# Altium schematic sheet [03] - PowerSupply.SchDoc
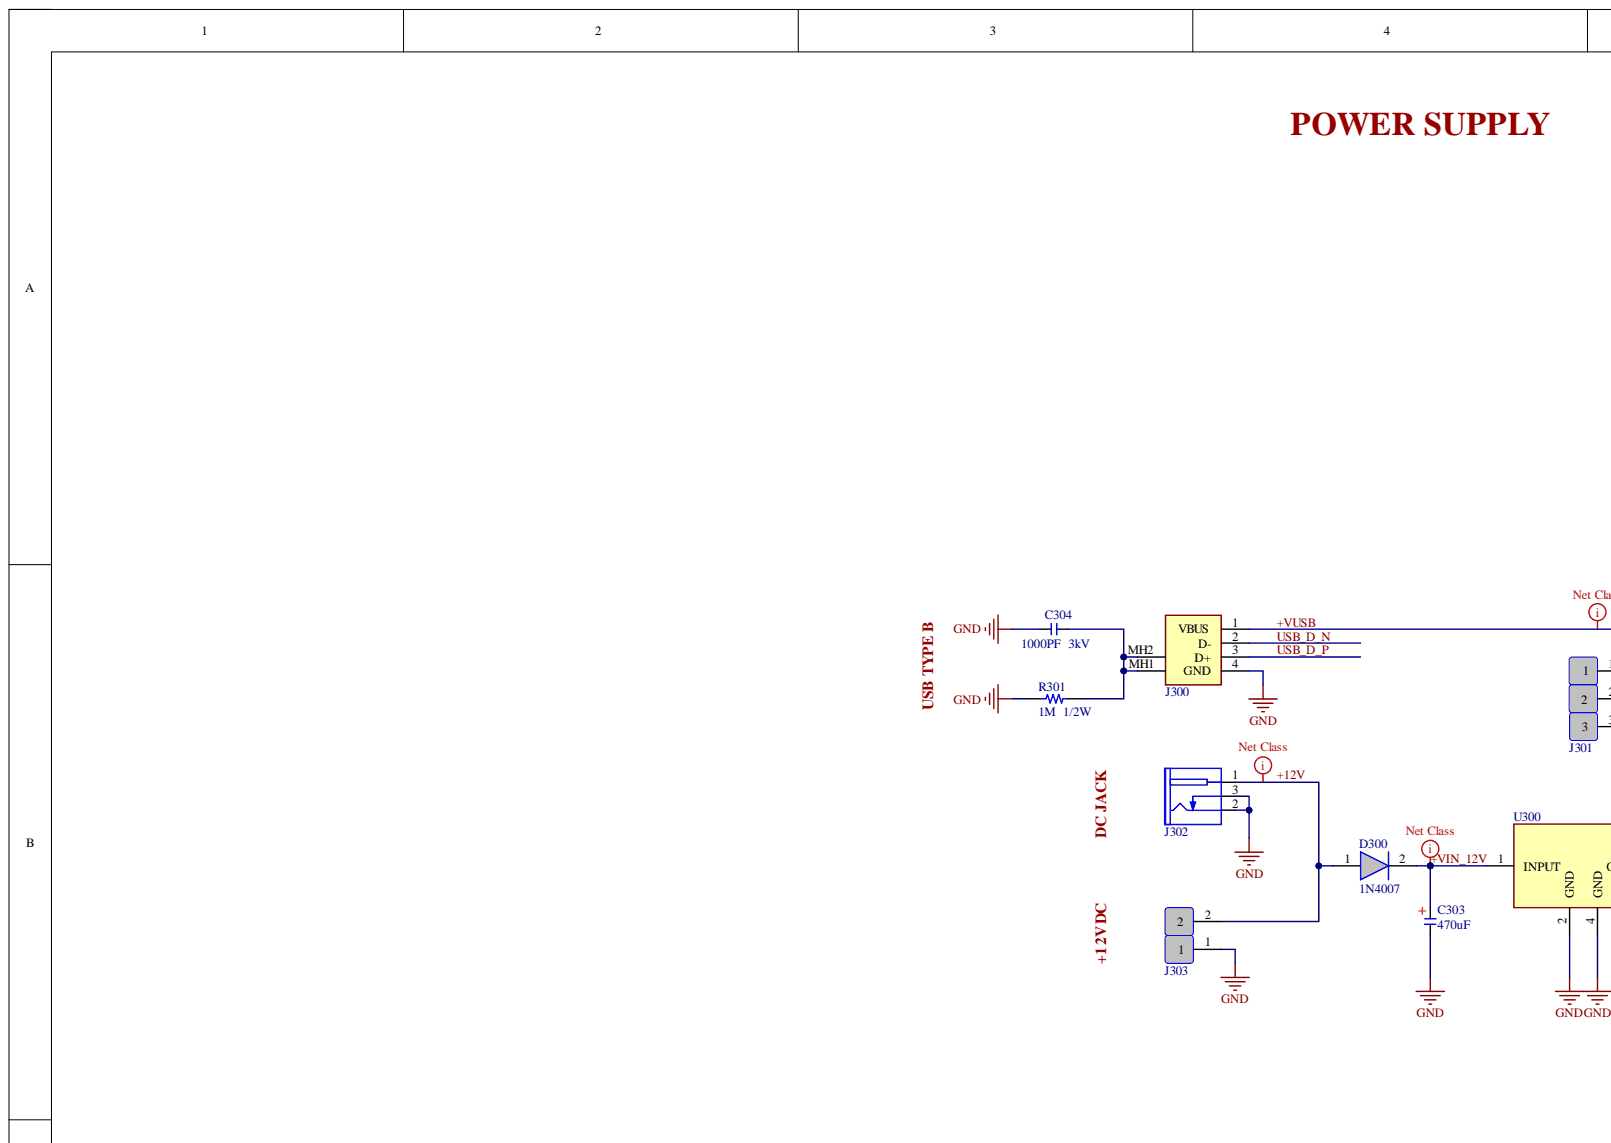
[diagram p0: [03] - PowerSupply.SchDoc, top left]
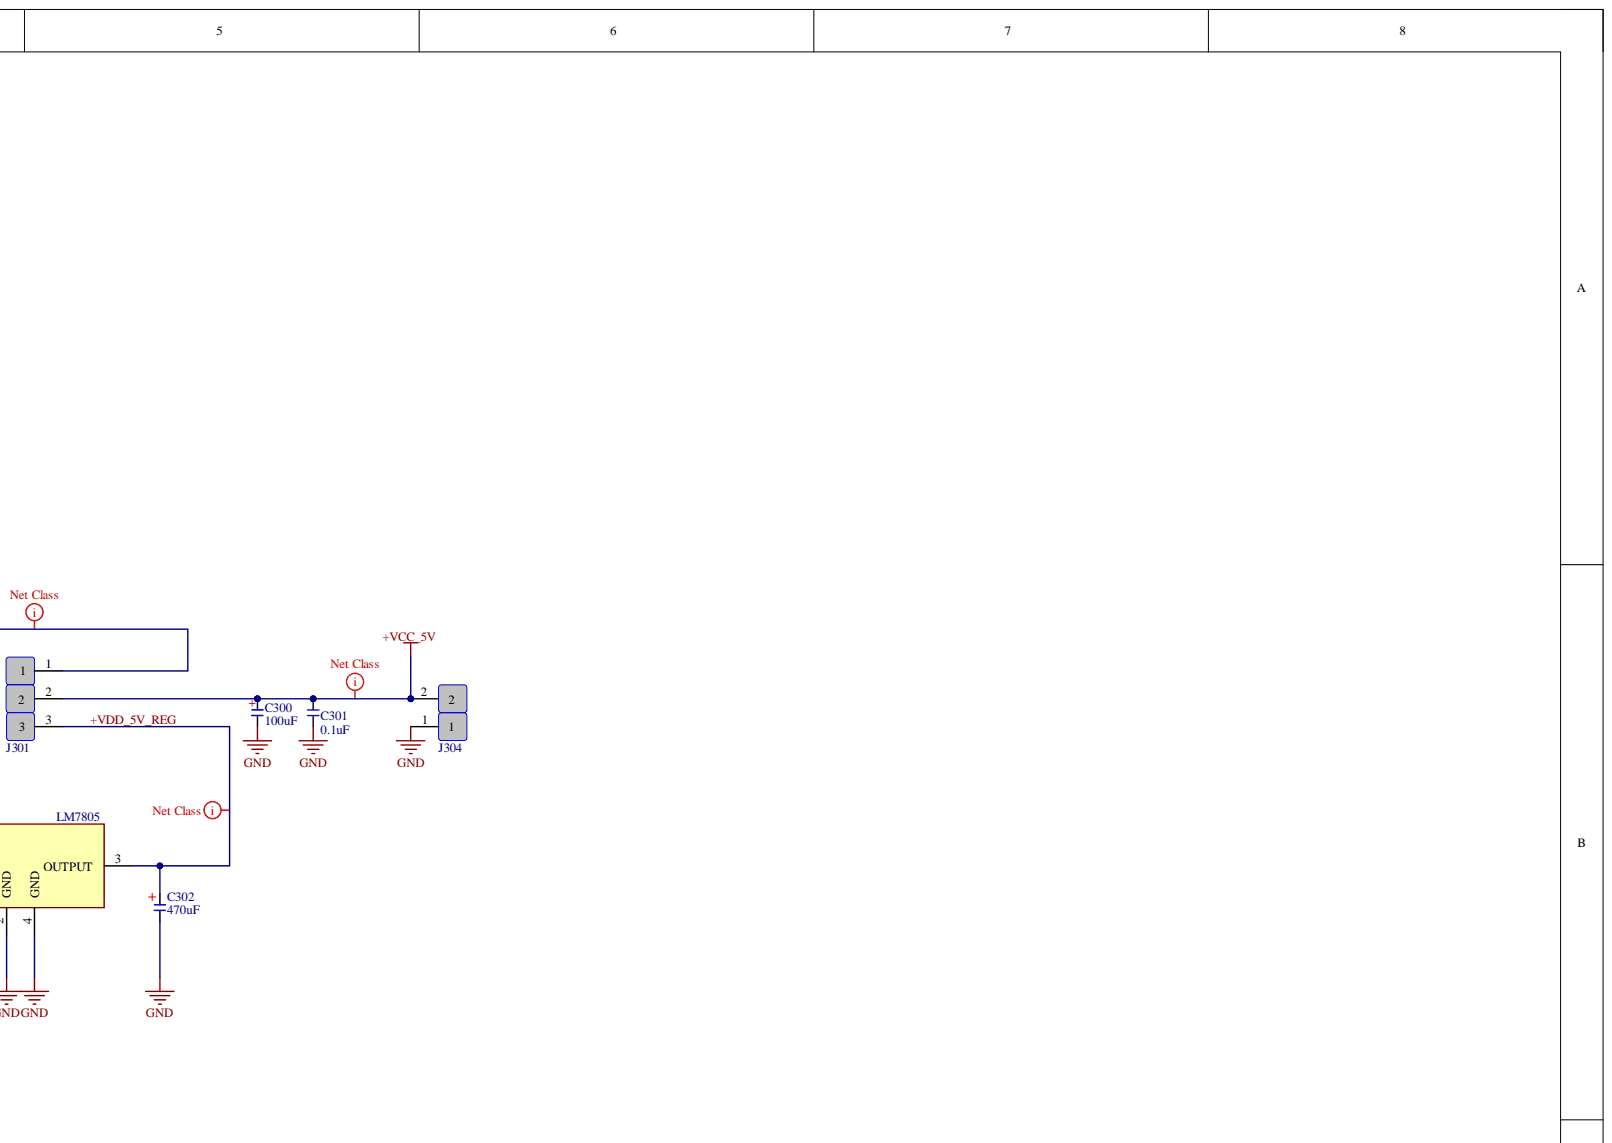
[diagram p1: [03] - PowerSupply.SchDoc, top right]
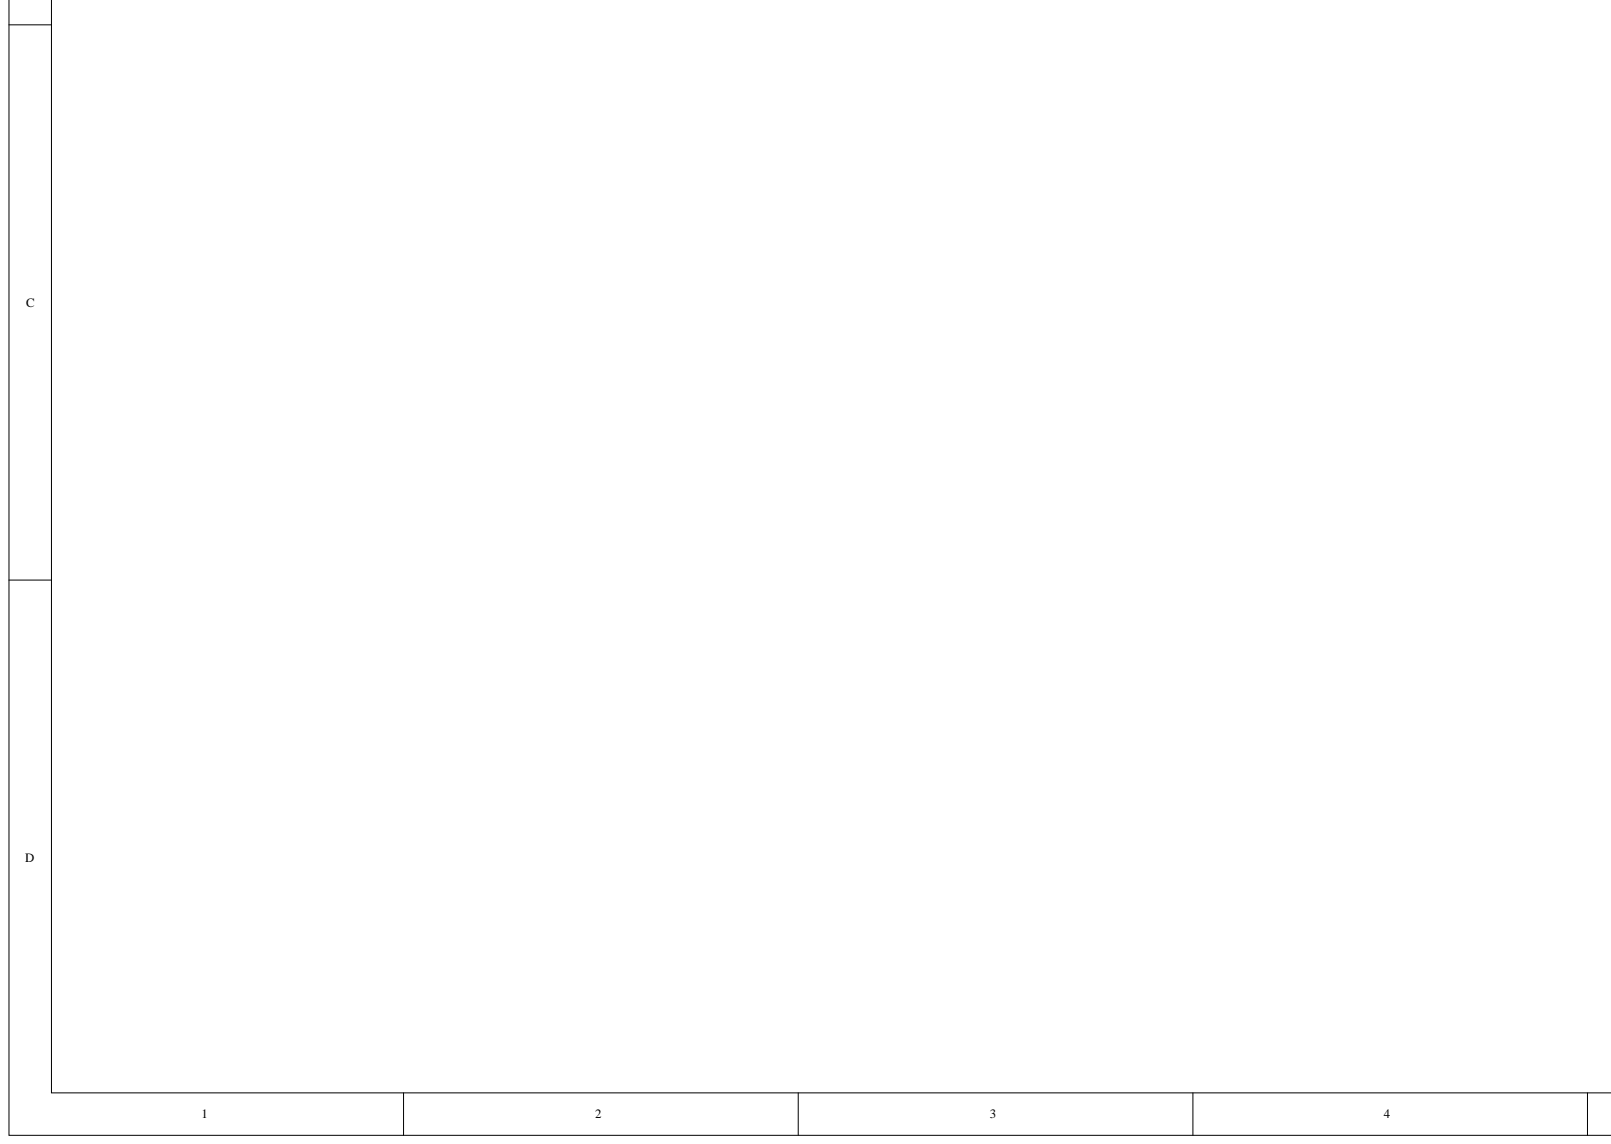
[diagram p2: [03] - PowerSupply.SchDoc, bottom left]
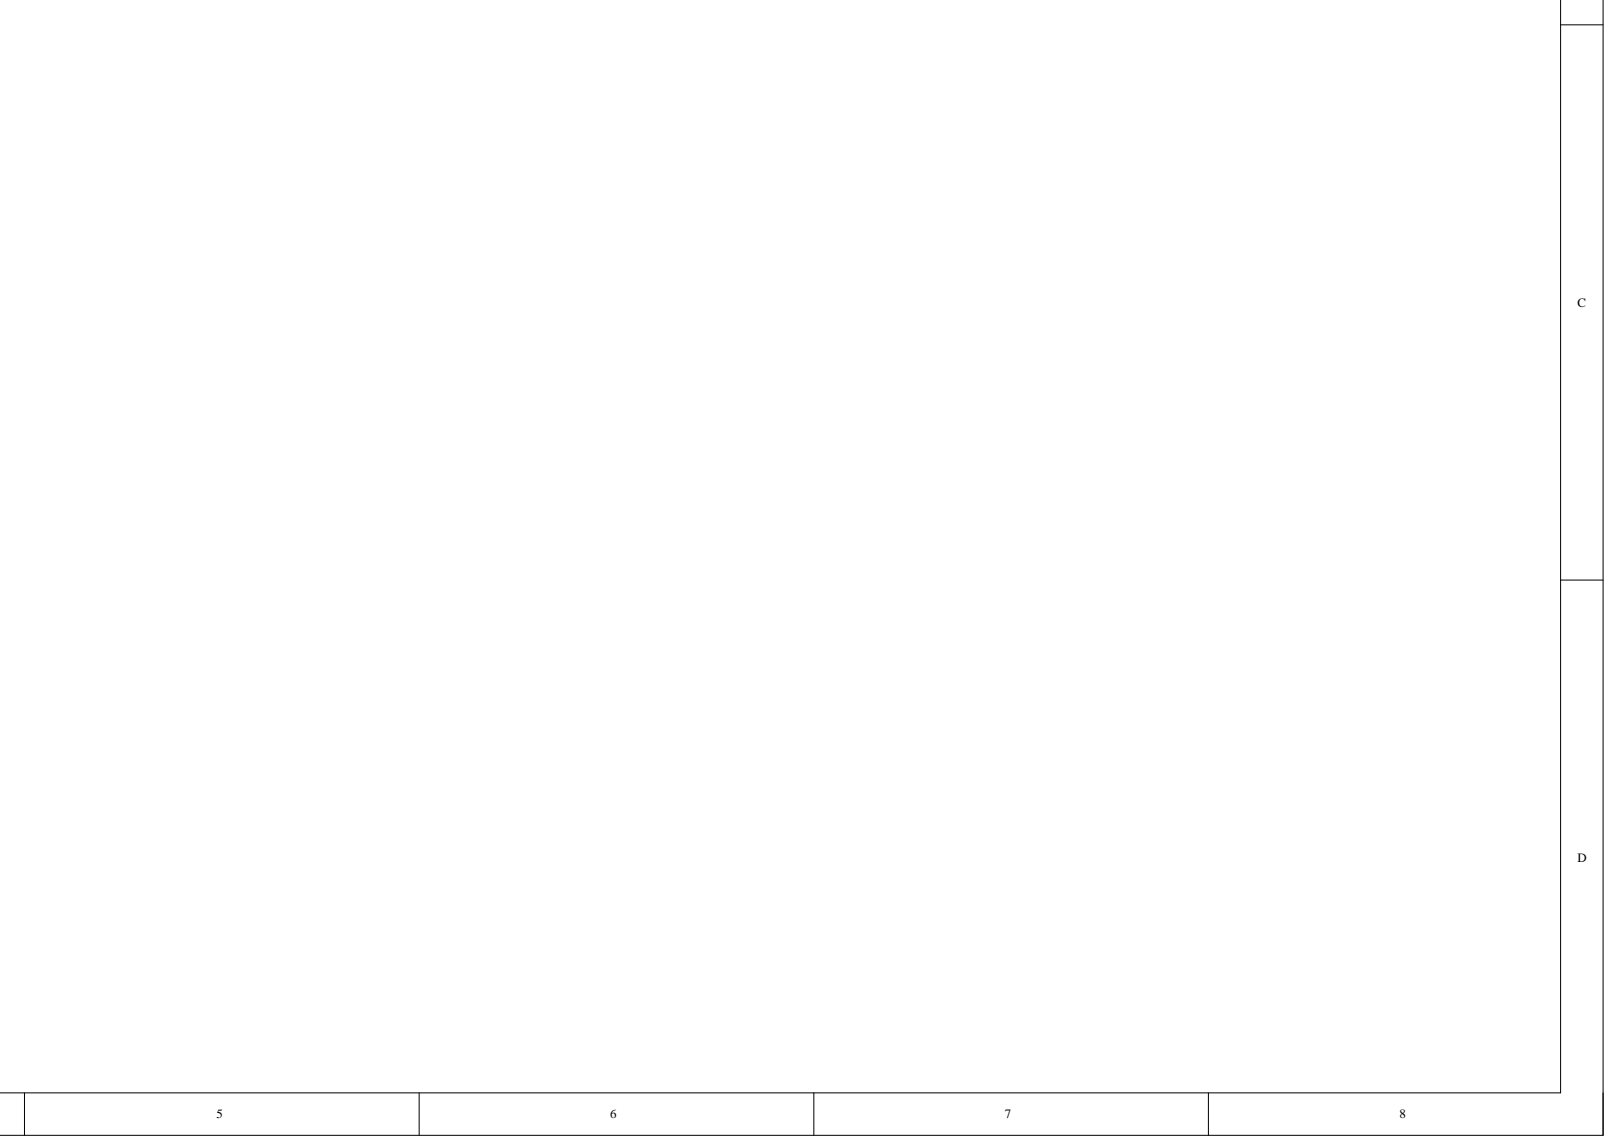
[diagram p3: [03] - PowerSupply.SchDoc, bottom right]

## Parts
C300  100uF  [1411]
C301  0.1uF  [C0402]
C302  470uF  [470uF]
C303  470uF  [470uF]
C304  1000PF  3kV  Voltage Rating: 3kV  [C1812]
D300  1N4007  [1N4007]
J300  SS-52300-001  [USB _Type_B]  (value not drawn)
J301  61300311121  [Con_3Pin]  (value not drawn)
J302  PJ-002BH  [POWER_JACK_5.5_2.1MM_NS_V1.1]  (value not drawn)
J303  Con_2Pin  [61300211121]  (value not drawn)
J304  Con_2Pin  [61300211121]  (value not drawn)
R301  1M  1/2W  [R0805]
U300  LM7805  [7805_SOT223]

## Nets
+VCC_5V: C300.1 C301.1 J301.2 J304.2
GND: C300.2 C301.2 C302.2 C303.2 C304.1 J300.4(GND) J302.2 J302.3 J303.1 J304.1 R301.2 U300.2(GND) U300.4(GND)
+12V: D300.1 J302.1 J303.2
+VDD_5V_REG: C302.1 J301.3 U300.3(OUTPUT)
+VIN_12V: C303.1 D300.2 U300.1(INPUT)
+VUSB: J300.1(VBUS) J301.1
USB_D_N: J300.2(D-)
USB_D_P: J300.3(D+)
NetC304_2: C304.2 J300.MH1 J300.MH2 R301.1

## Library data of the parts
C300 = C_100uF: KEMET - T520B107M006ATE018 - Tantalum Polymer Capacitor, KO-CAP®, 100 µF, 6.3 V, T52X KO-CAP® Series, ± 20%, B, 0.018 ohm; Dissipation Factor: 8%; ESR (Equivalent Series Resistance): 18mR; Life (Hours): 2000Hour; Polarity: Polar; Resistance: 0.018R; Voltage Rating: 6.3V; Capacitance: 100uF; Case Code (Imperial): 1411; Case Code (Metric): 3528; Case/Package: 1411; Category: Polymer Capacitors; Component Kind: Standard; ComponentLink1Description: https://datasheet.ciiva.com/22691/1759375-22691466.pdf?src-supplier=Element14; ComponentLink1URL: https://datasheet.ciiva.com/22691/1759375-22691466.pdf?src-supplier=Element14; ComponentLink2Description: https://datasheet.ciiva.com/260/kem-t2015-t520-260432.pdf?src-supplier=Digi-Key; ComponentLink2URL: https://datasheet.ciiva.com/260/kem-t2015-t520-260432.pdf?src-supplier=Digi-Key; ComponentLink3Description: https://datasheet.ciiva.com/260/kem-t2015-t520-260430.pdf?src-supplier=Digi-Key; ComponentLink3URL: https://datasheet.ciiva.com/260/kem-t2015-t520-260430.pdf?src-supplier=Digi-Key; ComponentLink4Description: https://datasheet.ciiva.com/260/kem-t2015-t520-260431.pdf?src-supplier=Digi-Key; ComponentLink4URL: https://datasheet.ciiva.com/260/kem-t2015-t520-260431.pdf?src-supplier=Digi-Key; Depth: 2.8mm; Dielectric Material: Tantalum; Features: General Purpose; Height: 2.1mm; Height - Seated (Max): 0.083inch; Length: 3.5mm; Library Name: Passive.SchLib; Manufacturer: KEMET; Manufacturer Part Number: T520B107M006ATE018; Max Operating Temperature: 105°C; Min Operating Temperature: -55°C; Mount: Surface Mount; Number of Pins: 2; Package Quantity: 1; Packaging: Tape and Reel; Pricing 1: 1=1.30000, 10=1.02800, 100=0.77110, 500=0.58260, 1000=0.51405 (USD); Radiation Hardening: No; REACH SVHC: No SVHC; RoHS: Yes; Stock 1: 28498; Supplier 1: Digi-Key; Supplier Part Number 1: 399-5308-1-ND; Termination: SMD/SMT; Tolerance: 20%; Voltage Rating (DC): 6.3V; Width: 0.11inch
C301 = C_0.1uF_0402: TDK - CGA2B3X7R1H104K050BB - KONDENSATOR, 0.1µF, 50V, 10%, X7R, 0402. ESR_18m Ohm; Capacitance: 100nF; Case Code (Imperial): 0402; Case Code (Metric): 1005; Case/Package: 0402; Component Kind: Standard; ComponentLink1Description: http://product.tdk.com/capacitor/mlcc/en/documents/mlccspec_automotive_general_midvoltage_en.pdf?src-supplier=Future+Electronics; ComponentLink1URL: http://product.tdk.com/capacitor/mlcc/en/documents/mlccspec_automotive_general_midvoltage_en.pdf?src-supplier=Future+Electronics; ComponentLink2Description: http://www.farnell.com/datasheets/1807242.pdf?src-supplier=Element14; ComponentLink2URL: http://www.farnell.com/datasheets/1807242.pdf?src-supplier=Element14; ComponentLink3Description: http://www.mouser.com/ds/2/400/lcc_automotive_general_en-843974.pdf?src-supplier=Mouser; ComponentLink3URL: http://www.mouser.com/ds/2/400/lcc_automotive_general_en-843974.pdf?src-supplier=Mouser; ComponentLink4Description: http://www.farnell.com/datasheets/1936565.pdf?src-supplier=Element14; ComponentLink4URL: http://www.farnell.com/datasheets/1936565.pdf?src-supplier=Element14; ComponentLink5Description: http://www.farnell.com/datasheets/1735392.pdf?src-supplier=Element14; ComponentLink5URL: http://www.farnell.com/datasheets/1735392.pdf?src-supplier=Element14; ComponentLink6Description: https://datasheet.ciiva.com/16498/mlccspec-automotive-general-midvoltage-en-16498528.pdf?src-supplier=Future+Electronics; ComponentLink6URL: https://datasheet.ciiva.com/16498/mlccspec-automotive-general-midvoltage-en-16498528.pdf?src-supplier=Future+Electronics; ComponentLink7Description: https://datasheet.ciiva.com/24216/cga2b3x7r1h104k050bb-266281-24216070.pdf?src-supplier=Mouser; ComponentLink7URL: https://datasheet.ciiva.com/24216/cga2b3x7r1h104k050bb-266281-24216070.pdf?src-supplier=Mouser; ComponentLink8Description: https://datasheet.ciiva.com/7975/1788388-7975306.pdf?src-supplier=Element14; ComponentLink8URL: https://datasheet.ciiva.com/7975/1788388-7975306.pdf?src-supplier=Element14; ComponentLink9Description: https://datasheet.ciiva.com/22703/1807242-22703013.pdf?src-supplier=Element14; ComponentLink9URL: https://datasheet.ciiva.com/22703/1807242-22703013.pdf?src-supplier=Element14; ComponentLink10Description: https://datasheet.ciiva.com/22725/1735392-22725627.pdf?src-supplier=Element14; ComponentLink10URL: https://datasheet.ciiva.com/22725/1735392-22725627.pdf?src-supplier=Element14; Composition: Ceramic; Depth: 0.5mm; Dielectric: X7R; Height: 0.5mm; Lead Free: Lead Free; Length: 1mm; Library Name: Passive.SchLib; Manufacturer 1: TDK; Manufacturer Part Number 1: CGA2B3X7R1H104K050BB; Max Operating Temperature: 125°C; Min Operating Temperature: -55°C; Mount: Surface Mount; Package Quantity: 1; Packaging: Tape and Reel; Pricing 1: 1=0.16000, 10=0.11700, 100=0.05500, 500=0.03858, 1000=0.03194, 2500=0.02973, 5000=0.02720 (USD); Ratings: AEC-Q200; REACH SVHC: No SVHC; RoHS: Yes; Stock 1: 9719460; Supplier 1: Digi-Key; Supplier Part Number 1: 445-6899-1-ND; Termination: SMD/SMT; Thickness: 0.022inch; Tolerance: 10%; Voltage: 50V; Voltage Rating: 50V; Voltage Rating (DC): 50V; Weight: 2.3E-05oz; Width: 0.02inch
C302 C303 = C_470uF: Capacitor;  Alum Lytic;  Cap 470 uF;  Tol 20%;  Vol-Rtg 50 VDC;  Radial;  12.5x20;  Tape&Box; Manufacturer: Panasonic; Manufacturer Part Number: EEU-FM1H471B; Dissipation Factor: 0.1%; ESR (Equivalent Series Resistance): 22mR; Lead Diameter: 0.6mm; Lead/Base Style: Straight; Life (Hours): 5000Hour; Polarity: Polar; Ripple Current: 2.26A; Voltage Rating: 50V; Capacitance: 470uF; Case/Package: Radial; Category: Aluminum Electrolytic Capacitors; Component Kind: Standard; ComponentLink1Description: https://datasheet.ciiva.com/14596/70068540-14596357.pdf?src-supplier=Allied+Electronics; ComponentLink1URL: https://datasheet.ciiva.com/14596/70068540-14596357.pdf?src-supplier=Allied+Electronics; ComponentLink2Description: https://datasheet.ciiva.com/11677/aba0000ce108-11677647.pdf?src-supplier=Avnet; ComponentLink2URL: https://datasheet.ciiva.com/11677/aba0000ce108-11677647.pdf?src-supplier=Avnet; Diameter: 12mm; Height: 20mm; Height - Seated (Max): 0.866inch; Impedance: 22mR; Lead Free: Lead Free; Lead Pitch: 5mm; Length: 20mm; Library Name: Passive.SchLib; Max Operating Temperature: 105°C; Min Operating Temperature: -40°C; Mount: Through Hole,PCB; Package Quantity: 500; Packaging: Tape and Reel; Pricing 1: 1=0.92000, 10=0.70000, 100=0.50110 (USD); Radiation Hardening: No; RoHS: Yes; Stock 1: 6561; Supplier 1: Digi-Key; Supplier Part Number 1: P19717CT-ND; Termination: Radial; Tolerance: 20%; Voltage Rating (DC): 50V
C304 = C_1000PF_3kV: CAP CER 1000PF 3KV X7R 1812; Manufacturer: Kyocera AVX; Manufacturer Part Number: 1812HC102KAZ1A; Capacitance: 1nF; Tolerance: 10%; Case Code (Imperial): 1812; Case Code (Metric): 4532; Case/Package: 1812; Component Kind: Standard; ComponentLink1Description: http://catalogs.avx.com/SurfaceMount.pdf?src-supplier=Digi-Key; ComponentLink1URL: http://catalogs.avx.com/SurfaceMount.pdf?src-supplier=Digi-Key; ComponentLink2Description: http://datasheets.avx.com/HV-FlexitermMLCC.pdf?src-supplier=Digi-Key; ComponentLink2URL: http://datasheets.avx.com/HV-FlexitermMLCC.pdf?src-supplier=Digi-Key; ComponentLink3Description: http://www.avxcorp.com/docs/catalogs/flexitermhv.pdf?src-supplier=Avnet; ComponentLink3URL: http://www.avxcorp.com/docs/catalogs/flexitermhv.pdf?src-supplier=Avnet; ComponentLink4Description: http://www.avx.com/docs/Catalogs/flexitermhv.pdf?src-supplier=Element14; ComponentLink4URL: http://www.avx.com/docs/Catalogs/flexitermhv.pdf?src-supplier=Element14; Depth: 3.2mm; Dielectric: X7R; Features: Soft Termination; Height: 2.54mm; Lead Free: Contains Lead; Length: 4.5mm; Library Name: Passive.SchLib; Max Operating Temperature: 125°C; Min Operating Temperature: -55°C; Mount: Surface Mount; Number of Pins: 2; Package Quantity: 1000; Packaging: Tape and Reel; Pricing 1: 1=1.61, 10=1.27, 100=0.9522, 500=0.71944 (USD); REACH SVHC: No SVHC; Stock 1: 10700; Supplier 1: Digi-Key; Supplier Part Number 1: 478-4607-1-ND; Termination: SMD/SMT; Thickness: 0.1inch; Voltage Rating (DC): 3kV; Width: 0.126inch; Created by: Arun Mohan
D300 = D_1N4007: NTE ELECTRONICS - 1N4007 - Repetitive Reverse Voltage Vrrm Max:1kV; Capacitance: 15pF; Current Rating: 1A; Element Configuration: Single; Forward Voltage: 480mV; Max Forward Surge Current (Ifsm): 30A; Max Repetitive Reverse Voltage (Vrrm): 1kV; Peak Reverse Current: 5uA; Polarity: Standard; Category: Rectifier Diodes; Component Kind: Standard; ComponentLink1Description: https://datasheet.ciiva.com/1239/1n4001-07-1239312.pdf?src-supplier=Newark; ComponentLink1URL: https://datasheet.ciiva.com/1239/1n4001-07-1239312.pdf?src-supplier=Newark; ComponentLink2Description: https://datasheet.ciiva.com/7624/1n4001-07-7624425.pdf?src-supplier=Element14; ComponentLink2URL: https://datasheet.ciiva.com/7624/1n4001-07-7624425.pdf?src-supplier=Element14; ComponentLink3Description: https://datasheet.ciiva.com/16235/1n4001-07-16235595.pdf?src-supplier=Onlinecomponents.com; ComponentLink3URL: https://datasheet.ciiva.com/16235/1n4001-07-16235595.pdf?src-supplier=Onlinecomponents.com; Forward Current: 1A; Lead Free: Lead Free; Library Name: D FUN D_Lib.SchLib; Manufacturer: NTE Electronics; Manufacturer Part Number: 1N4007; Max Operating Temperature: 150°C; Min Operating Temperature: -65°C; Mount: Through Hole; Number of Pins: 2; Output Current: 1A; Packaging: Cut Tape; Peak Non-Repetitive Surge Current: 30A; REACH SVHC: Unknown; RoHS: Yes; Supplier 1: Allied Electronics; Supplier Part Number 1: 70723033; Voltage Rating (DC): 1kV
J300 = USB_typeB: Usb 2.0 Right Angle Receptacle Type B Rohs Compliant: Yes; Manufacturer: Bel; Component Kind: Standard; Library Name: Connectors.SchLib; Manufacturer Part Number: SS-52300-001; Pricing 1: 1=0.99000, 10=0.86700, 25=0.81600, 50=0.78200, 100=0.74800, 250=0.68000, 500=0.61200, 1000=0.54400, 2500=0.49300 (USD); RoHS: No; Stock 1: 1076; Supplier 1: Digi-Key; Supplier Part Number 1: 380-1492-ND; value: SS-52300-001
J301 = Con_3Pin: Board-To-Board Connector, Vertical, Wr-Phd Series, Through Hole, Header, 3, 2.54 Mm; Manufacturer: Wurth Electronics; Connector Type: Male Pin; Gender: Male; Number of Contacts: 3; Pitch: 0.1inch; Category: Connectors; Color: Black; Component Kind: Standard; ComponentLink1Description: http://www.farnell.com/cad/1737268.pdf?src-supplier=Element14; ComponentLink1URL: http://www.farnell.com/cad/1737268.pdf?src-supplier=Element14; ComponentLink2Description: https://katalog.we-online.de/em/datasheet/6130xx11121.pdf?src-supplier=Digi-Key; ComponentLink2URL: https://katalog.we-online.de/em/datasheet/6130xx11121.pdf?src-supplier=Digi-Key; Contact Material: Copper; Contact Mating Length: 0.236inch; Contact Plating: Gold; Lead Free: Lead Free; Library Name: Connectors.SchLib; Manufacturer Part Number: 61300311121; Mount: Through Hole; Number of Positions: 3; Number of Rows: 1; Packaging: Bulk; Pricing 1: 1=0.13000, 10=0.10600, 25=0.08800, 50=0.07400, 100=0.06700, 1000=0.06100 (USD); REACH SVHC: Unknown; RoHS: Yes; Stock 1: 51140; Supplier 1: Digi-Key; Supplier Part Number 1: 732-5316-ND; Termination: Solder
J302 = DC Jack: CONN PWR JACK 2.5X5.5MM SOLDER; Manufacturer: CUI; Current Rating: 5A; Gender: Male; Insulation Resistance: 100MR; Number of Conductors: 2; Shielding: Unshielded; Voltage Rating: 24V; Color: Black; Component Kind: Standard; Connector Type: Jack; Contact Gender: Male; Contact Material: Copper,Copper Alloy; Contact Plating: Nickel; Diameter: 2.5mm; Flammability Rating: UL94 V-0; Housing Color: Black; Housing Material: Thermoplastic; Lead Free: Lead Free; Library Name: Connectors.SchLib; Manufacturer Part Number: PJ-002BH; Max Operating Temperature: 85°C; Min Operating Temperature: -25°C; Mount: Through Hole; Orientation: Horizontal; Package Quantity: 500; Packaging: Bulk; Pricing 1: 1=0.74, 10=0.696, 25=0.6264, 50=0.5568, 100=0.5336, 250=0.4872, 500=0.464, 1000=0.3828, 2500=0.348 (USD); Stock 1: 1916; Supplier 1: Digi-Key; Supplier Part Number 1: CP-002BH-ND; Termination: Through Hole
J303 J304 = Con_2Pin: CONN HEADER 2 POS 2.54; Manufacturer: Wurth Electronics Inc.; Contact Length - Mating: 0.236" (6.00mm); Contact Length - Post: 0.118" (3.00mm); Current Rating: 3A; Material Flammability Rating: UL94 V-0; Number of Positions: 2; Number of Positions Loaded: All; Overall Contact Length: 0.454" (11.54mm); Pitch - Mating: 0.100" (2.54mm); Shrouding: Unshrouded; Style: Board to Board or Cable; Voltage Rating: 250V; Applications: -; Category: Connectors, Interconnects; Component Kind: Standard; ComponentLink1Description: https://katalog.we-online.de/em/datasheet/6130xx11121.pdf; ComponentLink1URL: https://katalog.we-online.de/em/datasheet/6130xx11121.pdf; Connector Type: Header; Contact Finish - Mating: Gold; Contact Finish - Post: -; Contact Finish Thickness - Mating: -; Contact Finish Thickness - Post: -; Contact Material: Copper Alloy; Contact Shape: Square; Contact Type: Male Pin; Fastening Type: Push-Pull; Features: -; Ingress Protection: -; Insulation Color: Black; Insulation Height: 0.100" (2.54mm); Insulation Material: Polybutylene Terephthalate (PBT); Library Name: Connectors.SchLib; Manufacturer Part Number: 61300211121; Mated Stacking Heights: -; Mounting Type: Through Hole; Number of Rows: 1; Operating Temperature: -40°C ~ 125°C; Packaging: Bulk; Part Status: Active; Pricing 1: 1=0.13, 10=0.106, 25=0.088, 50=0.074, 100=0.067, 1000=0.061 (USD); RoHS: RoHS Compliant; Row Spacing - Mating: -; Stock 1: 170502; Supplier 1: Digi-Key; Supplier Part Number 1: 732-5315-ND; Termination: Solder
R301 = R_1MOhm_0805_0.5W: RES SMD 1M OHM 1% 1/2W 0805; Manufacturer: Vishay Dale; Manufacturer Part Number: CRCW08051M00FKEAHP; Max Power Dissipation: 333mW; Power Rating: 330mW; Resistance: 1MR; Temperature Coefficient: 100ppm/°C; Voltage Rating: 150V; Case Code (Imperial): 0805; Case Code (Metric): 2012; Case/Package: 0805; Component Kind: Standard; ComponentLink1Description: http://www.vishay.com/docs/20043/crcwhpe3.pdf?src-supplier=Digi-Key; ComponentLink1URL: http://www.vishay.com/docs/20043/crcwhpe3.pdf?src-supplier=Digi-Key; ComponentLink2Description: http://www.vishay.com/docs/20043/crcwhpe3.pdf?src-supplier=Avnet; ComponentLink2URL: http://www.vishay.com/docs/20043/crcwhpe3.pdf?src-supplier=Avnet; ComponentLink3Description: http://www.mouser.com/ds/2/427/crcwhpe3-46056.pdf?src-supplier=Mouser; ComponentLink3URL: http://www.mouser.com/ds/2/427/crcwhpe3-46056.pdf?src-supplier=Mouser; Composition: Thick Film; Contact Plating: Tin; Features: Automotive AEC-Q200; Height: 0.024inch; Lead Free: Lead Free; Length: 2mm; Library Name: Passive.SchLib; Max Operating Temperature: 155°C; Min Operating Temperature: -55°C; Mount: Surface Mount; Number of Terminations: 2; Package Quantity: 10; Packaging: Tape and Reel; Pricing 1: 1=0.28, 10=0.233, 100=0.0911, 1000=0.03812, 2500=0.03494 (USD); Radiation Hardening: No; Stock 1: 5523; Supplier 1: Digi-Key; Supplier Part Number 1: 541-1.00MTCT-ND; Termination: SMD/SMT; Tolerance: 1%; Weight: 0.000194oz; Width: 0.049inch
U300 = LM7805MPX/NOPB: IC REG LINEAR 5V 1.5A SOT223-4; Manufacturer: Texas Instruments; Dropout Voltage: 2V; Quiescent Current: 8mA; Category: Voltage Regulators - Linear; Component Kind: Standard; Lead Free: Lead Free; Library Name: D FUN D_Lib.SchLib; Manufacturer Part Number: LM7805MPX/NOPB; Max Operating Temperature: 125°C; Min Operating Temperature: 0°C; Pricing 1: 2000=0.51580, 6000=0.49880, 10000=0.48330, 24000=0.47680 (USD); RoHS: No; Stock 1: 2000; Supplier 1: Arrow; Supplier Part Number 1: LM7805MPX/NOPB
